# Altium schematic sheet LIDO-HW.200.SecurityAndTemperature.SchDoc(LIDO-HW.200.SecurityAndTemperature)
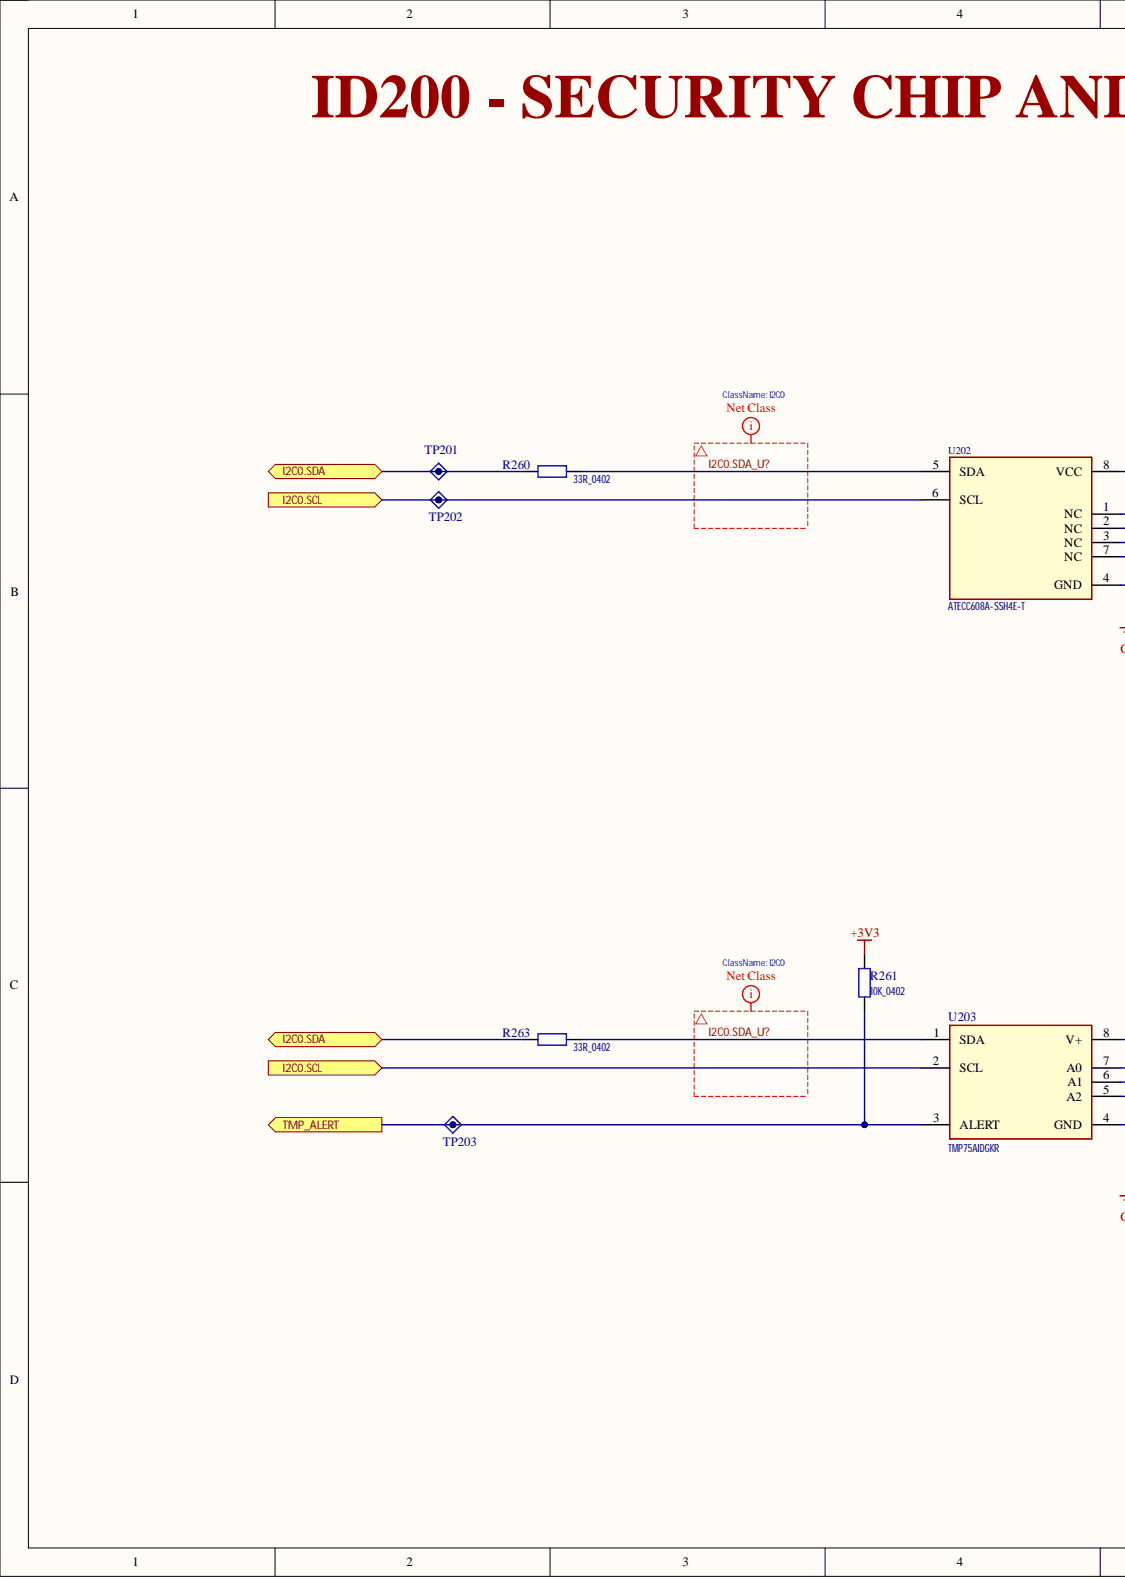
[diagram p0: LIDO-HW.200.SecurityAndTemperature.SchDoc, left]
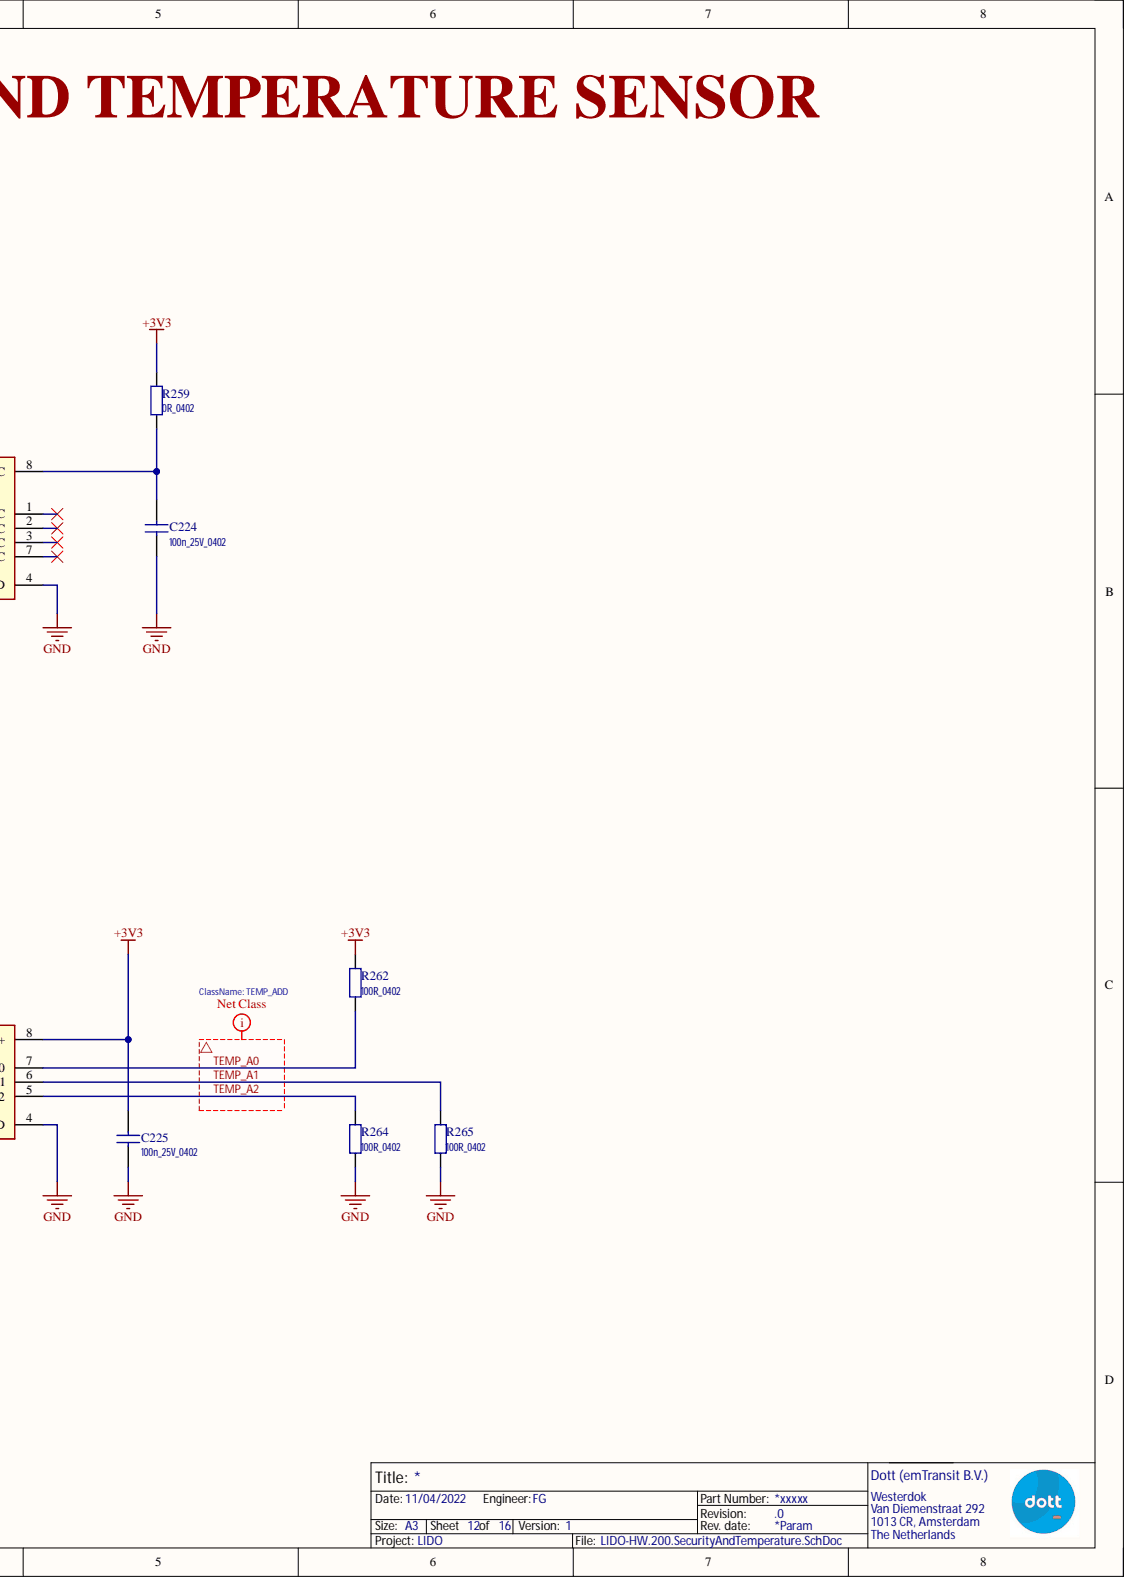
[diagram p1: LIDO-HW.200.SecurityAndTemperature.SchDoc, right]

## Parts
C224  100n_25V_0402
C225  100n_25V_0402
R259  0R_0402
R260  33R_0402
R261  10K_0402
R262  100R_0402
R263  33R_0402
R264  100R_0402
R265  100R_0402
TP201  TestPoint_A  (value not drawn)  (no BOM)
TP202  TestPoint_A  (value not drawn)  (no BOM)
TP203  TestPoint_A  (value not drawn)  (no BOM)
U202  ATECC608A-SSH4E-T  [SOIC8P127_490X600X175L83X42N]
U203  TMP75AIDGKR  [SOP8P65_300X490X110L55X31N]

## Ports
I2C0.SCL, I2C0.SDA, TMP_ALERT

## Nets
+3V3: C225.1 R259.2 R261.1 R262.1 U203.8(V+)
GND: C224.2 C225.2 R264.2 R265.2 U202.4(GND) U203.4(GND)
I2C0.SCL: TP202.1 U202.6(SCL) U203.2(SCL) ; port I2C0.SCL
I2C0.SDA: R260.2 R263.2 TP201.1 ; port I2C0.SDA
I2C0.SDA_U?: R260.1 R263.1 U202.5(SDA) U203.1(SDA)
TEMP_A0: R262.2 U203.7(A0)
TEMP_A1: R265.1 U203.6(A1)
TEMP_A2: R264.1 U203.5(A2)
TMP_ALERT: R261.2 TP203.1 U203.3(ALERT) ; port TMP_ALERT
NetC224_1: C224.1 R259.1 U202.8(VCC)

Not connected: U202 1(NC) 2(NC) 3(NC) 7(NC)

## Library data of the parts
C224 C225 = Ceramic: Ceramic CAP Symbol; Component Kind: Standard; Library Name: 01_Capacitors.SchLib
R259-R265 = Resistor: Resistor Symbol; Component Kind: Standard; Library Name: 02_Resistors.SchLib
TP201-TP203 = TestPoint_A: Test Point Symbol verion A; 00_Name: TP_XXmm; 01_Diameter: 1mm; Library Name: 31_MFG_Elements.SchLib
U202 = ATECC608A-SSHDA_A: IC AUTHENTICATION CHIP 8SOIC, custom for Dott; Manufacturer: Microchip Technology; Designer: Dekimo; Ratings: RoHS; Reviewed: Dekimo_30-06-2020; Case/Package: 8-SOIC; Component Kind: Standard; ComponentType: IC; Design Date: N/A; Manufacturer Part Number: ATECC608A-SSH4E-T; Max Operating Temperature: 85°C; Min Operating Temperature: -40°C; Value: ATECC608A-SSH4E-T; Library Name: 15_IC_Specialized.SchLib
U203 = TMP75AIDGKR_A: Temperature Sensor Digital, Local -40°C ~ 125°C 11 b 8-VSSOP; Manufacturer: Texas Instruments; Designer: Matt Drazenovich; Ratings: RoHS; Reviewed: Dekimo_07-07-2020; Case/Package: 8-VSSOP; Component Kind: Standard; ComponentType: IC; Design Date: 03-07-2020; Library Name: 16_Sensors_Temperature.SchLib; Manufacturer Part Number: TMP75AIDGKR; Max Operating Temperature: 127°C; Min Operating Temperature: -40°C; Value: TMP75AIDGKR
